# Altium schematic sheet laser_power_VRC.SchDoc
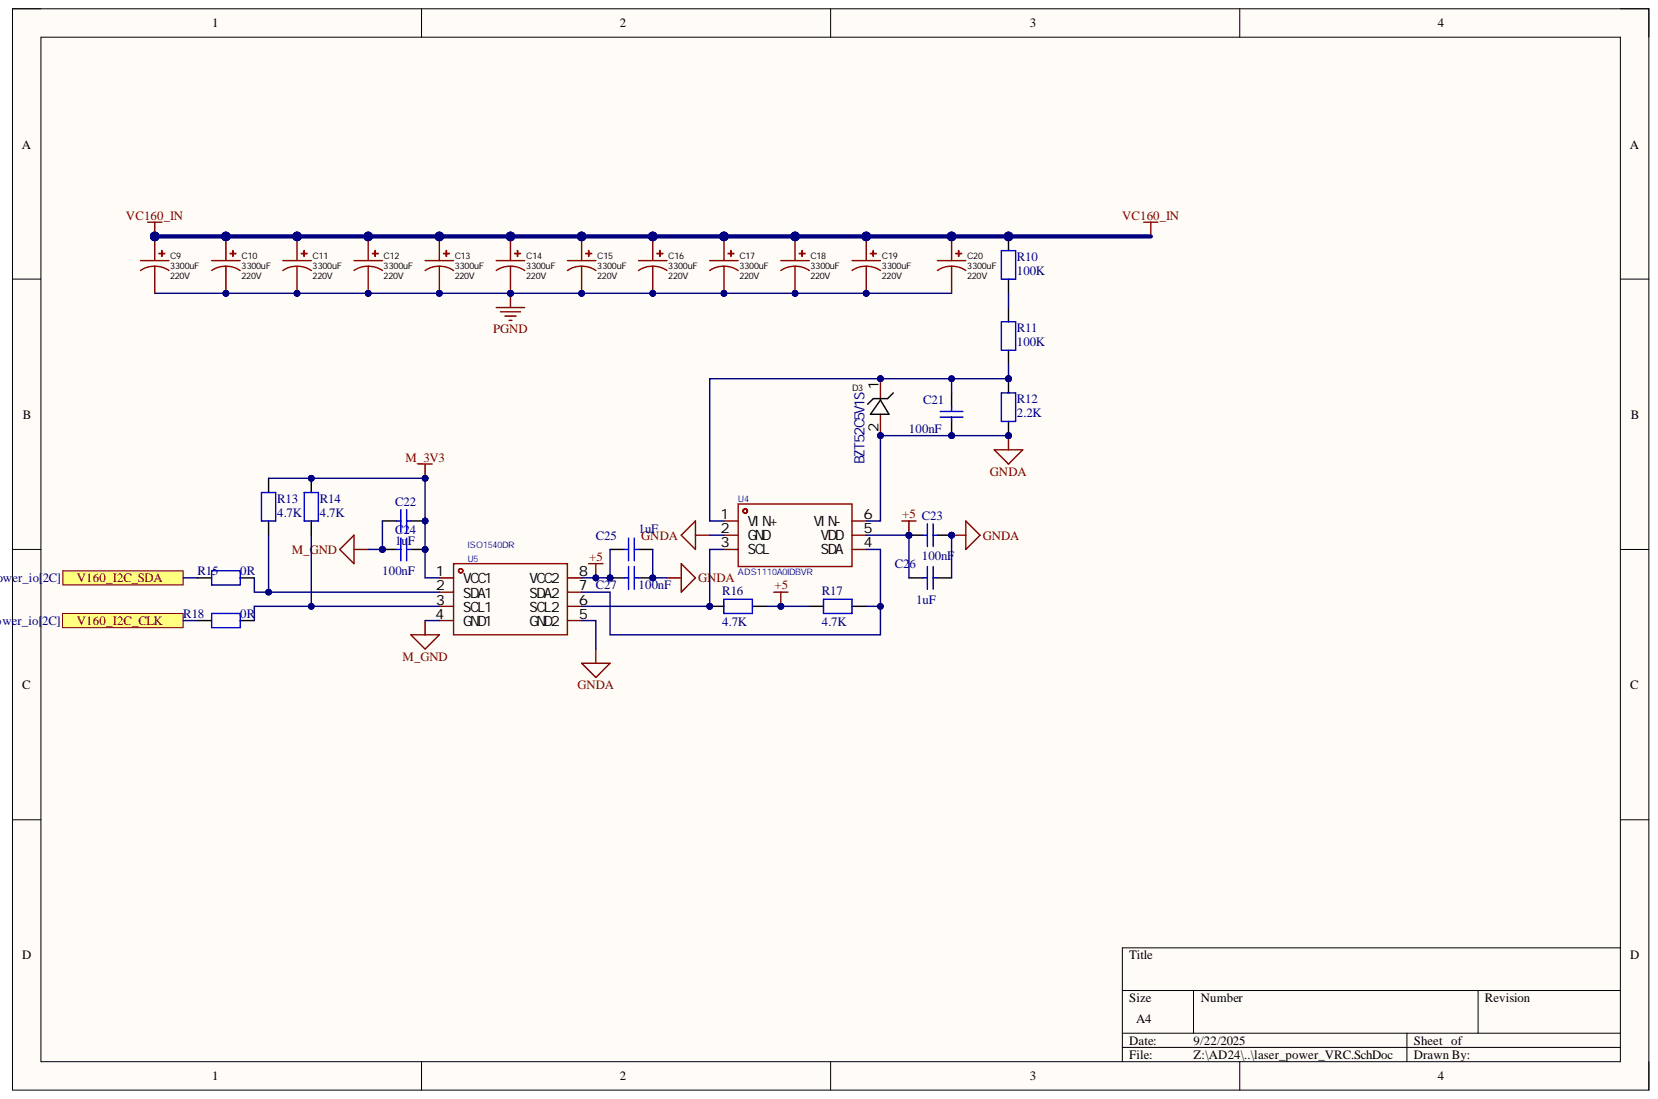
[diagram p0: laser_power_VRC.SchDoc]

## Parts
C9  3300uF  [CAP-TH_BD35.0-P10.00-D2.3-FD]
C10  3300uF  [CAP-TH_BD35.0-P10.00-D2.3-FD]
C11  3300uF  [CAP-TH_BD35.0-P10.00-D2.3-FD]
C12  3300uF  [CAP-TH_BD35.0-P10.00-D2.3-FD]
C13  3300uF  [CAP-TH_BD35.0-P10.00-D2.3-FD]
C14  3300uF  [CAP-TH_BD35.0-P10.00-D2.3-FD]
C15  3300uF  [CAP-TH_BD35.0-P10.00-D2.3-FD]
C16  3300uF  [CAP-TH_BD35.0-P10.00-D2.3-FD]
C17  3300uF  [CAP-TH_BD35.0-P10.00-D2.3-FD]
C18  3300uF  [CAP-TH_BD35.0-P10.00-D2.3-FD]
C19  3300uF  [CAP-TH_BD35.0-P10.00-D2.3-FD]
C20  3300uF  [CAP-TH_BD35.0-P10.00-D2.3-FD]
C21  100nF  [0805]
C22  1uF  [0603L]
C23  100nF  [0603]
C24  100nF  [0603]
C25  1uF  [0603L]
C26  1uF  [0603L]
C27  100nF  [0603]
D3  BZT52C5V1S  [SOD-323_L1.7-W1.3-LS2.5-FD]
R10  100K  [0805]
R11  100K  [0805]
R12  2.2K  [0805]
R13  4.7K  [R0603]
R14  4.7K  [R0603]
R15  0R  [R0603]
R16  4.7K  [R0603]
R17  4.7K  [R0603]
R18  0R  [R0603]
U4  ADS1110A0IDBVR  [SOT-23-6_L2.9-W1.6-P0.95-LS2.8-BR]
U5  ISO1540DR  [SOIC-8_L4.9-W3.9-P1.27-LS6.0-BL]

## Ports
V160_I2C_CLK, V160_I2C_SDA

## Nets
+5: C23.1 C25.1 C26.1 C27.1 R16.2 R17.1 U4.5(VDD) U5.8(VCC2)
GNDA: C21.1 C23.2 C25.2 C26.2 C27.2 D3.2 R12.2 U4.2(GND) U4.6(VIN-) U5.5(GND2)
M_3V3: C22.1 C24.2 R13.2 R14.2 U5.1(VCC1)
M_GND: C22.2 C24.1 U5.4(GND1)
PGND: C9.2 C10.2 C11.2 C12.2 C13.2 C14.2 C15.2 C16.2 C17.2 C18.2 C19.2 C20.2
VC160_IN: C9.1 C10.1 C11.1 C12.1 C13.1 C14.1 C15.1 C16.1 C17.1 C18.1 C19.1 C20.1 R10.1
V160_I2C_CLK: R18.2 ; port V160_I2C_CLK
V160_I2C_SDA: R15.2 ; port V160_I2C_SDA
NetC21_2: C21.2 D3.1 R11.2 R12.1 U4.1(VIN+)
NetR10_2: R10.2 R11.1
NetR13_1: R13.1 R15.1 U5.2(SDA1)
NetR14_1: R14.1 R18.1 U5.3(SCL1)
NetR16_1: R16.1 U4.3(SCL) U5.6(SCL2)
NetR17_2: R17.2 U4.4(SDA) U5.7(SDA2)

## Library data of the parts
C9-C20 = 450MXK680MEFCSN35X45: 220V; Manufacturer Part: CD2943000UF220V187; L: 45mm; Lifetime @ Temperature: 3000hrs@105℃; Ripple Current: 2.38A@120Hz; Tolerance: ±20%; φD: 35mm; Component Kind: Standard; Equivalent Series Resistance (ESR): -; LCSC Part Name: 3300uF ±20% 450V; Lead Spacing: 10mm; Library Name: HiPin_Library_AD.IntLib; Operating Temperature: -25℃~+105℃; PCB3D: CAP-TH_BD35.0-P10.00-D2.3-FD; Rated Voltage: 220V; Supplier Footprint: 插件,D35xL45mm; Value: 3300uF
C21 = Capacitor_2: 100V, X7R, 10%; Manufacturer: muRata; Manufacturer_Part_Number: GCM21BR72A104KA37L; Component Kind: Standard; Library Name: HiPin_Library_AD.IntLib
C22 C25 C26 = Capacitor_1: 16V, X5R, 10%; Internal_Part_Number: MCD-00009; Manufacturer: muRata; Manufacturer_Part_Number: GRM188R61E105KA12D; Component Kind: Standard; ComponentLink1Description: Yageo_Capacitor_X5R-series.pdf; ComponentLink1URL: http://tunmcdsvn.tun.st.com/Component_Datasheet_Library/Trunk/Capacitors/Yageo_Multilayer_capacitor_X5R_series_100pF_to_100uF; Library Name: HiPin_Library_AD.IntLib; Package: C0603; Value: 1uF
C23 = Capacitor_2: 50V, X7R, 10%; Manufacturer: muRata; Manufacturer_Part_Number: GRM188R71H104KA93D; Component Kind: Standard; Library Name: HiPin_Library_AD.IntLib
C24 C27 = Capacitor_2: 50V, X5R, 10%; Internal_Part_Number: MCD-00014; Manufacturer: muRata; Manufacturer_Part_Number: GRM21BR61E226ME44L; Value: 22uF; Component Kind: Standard; ComponentLink1Description: Datasheet; ComponentLink1URL: http://tunmcdsvn.tun.st.com/Component_Datasheet_Library/Trunk/Capacitors/Yageo_Multilayer_capacitor_X5R_series_100pF_to_100uF; Library Name: HiPin_Library_AD.IntLib
D3 = LM5Z3V6T1G: Manufacturer: CJ(江苏长电/长晶); Manufacturer Part: BZT52C6V2; Add into BOM: yes; Device: BZT52C6V2_C2910064; Diode Configuration: 独立式; Power Dissipation: 500mW; Reverse Leakage Current (Ir): 3uA@4V; Zener Impedance (Zzt): 10Ω; Zener Voltage (Nom): 6.2V; Zener Voltage (Range): 5.8V~6.6V; Component Kind: Standard; Convert to PCB: yes; Datasheet: https://atta.szlcsc.com/upload/public/pdf/source/20211105/0A7AF84D57D732370551C8DD7A10F563.pdf; JLCPCB Part Class: Extended Part; LCSC Part Name: BZT52C6V2; Library Name: HiPin_Library_AD.IntLib; Name: BZT52C6V2; PCB3D: SOD-123_L2.7-W1.6-H1.4-LS3.7; Supplier: LCSC; Supplier Footprint: SOD-123; Supplier Part: C2910064; Symbol: BZT52C6V2_C2910064; Tolerance: -
R10-R18 = RES: 1%; Value: 10K; Component Kind: Standard; Library Name: HiPin_Library_AD.IntLib
U4 = ADS1110A0IDBVR: Manufacturer: TI(德州仪器); Add into BOM: yes; Interface: I2C; number of channels: 1; Resolution(Bits): 16位; Sampling Rate: 15Hz; Voltage - Supply: 2.7V~5.5V; Component Kind: Standard; Convert to PCB: yes; Datasheet: http://www.ti.com/cn/lit/gpn/ads1110; Device: ADS1110A0IDBVR; differential input: -; Integral non - linearity: -; JLCPCB Part Class: Extended Part; LCSC Part Name: ADS1110A0IDBVR; Library Name: HiPin_Library_AD.IntLib; Manufacturer Part: ADS1110A0IDBVR; Operating Temperature: -; PCB3D: SOT-23-6_L2.9-W1.6-H1.5-LS2.8-P0.95; Supplier: LCSC; Supplier Footprint: SOT-23-6; Supplier Part: C16598; Symbol: ADS1110A0IDBVR
U5 = ISO1540DR: Manufacturer: TI(德州仪器); Add into BOM: yes; Clock Direction: 双向; CMTI(kV/us): 50kV/us; Isolation Voltage(Vrms): 2500; Component Kind: Standard; Convert to PCB: yes; Datasheet: https://www.ti.com/cn/lit/gpn/iso1541; Device: ISO1540DR; JLCPCB Part Class: Extended Part; LCSC Part Name: 低功耗双向I2C隔离器; Library Name: HiPin_Library_AD.IntLib; Manufacturer Part: ISO1540DR; Operating Temperature: -40℃~+125℃; PCB3D: SOIC-8_L4.9-W3.9-H1.7-LS6.0-P1.27; Supplier: LCSC; Supplier Footprint: SOIC-8; Supplier Part: C179739; Symbol: ISO1540DR
